# Altium schematic sheet main.SchDoc(main)
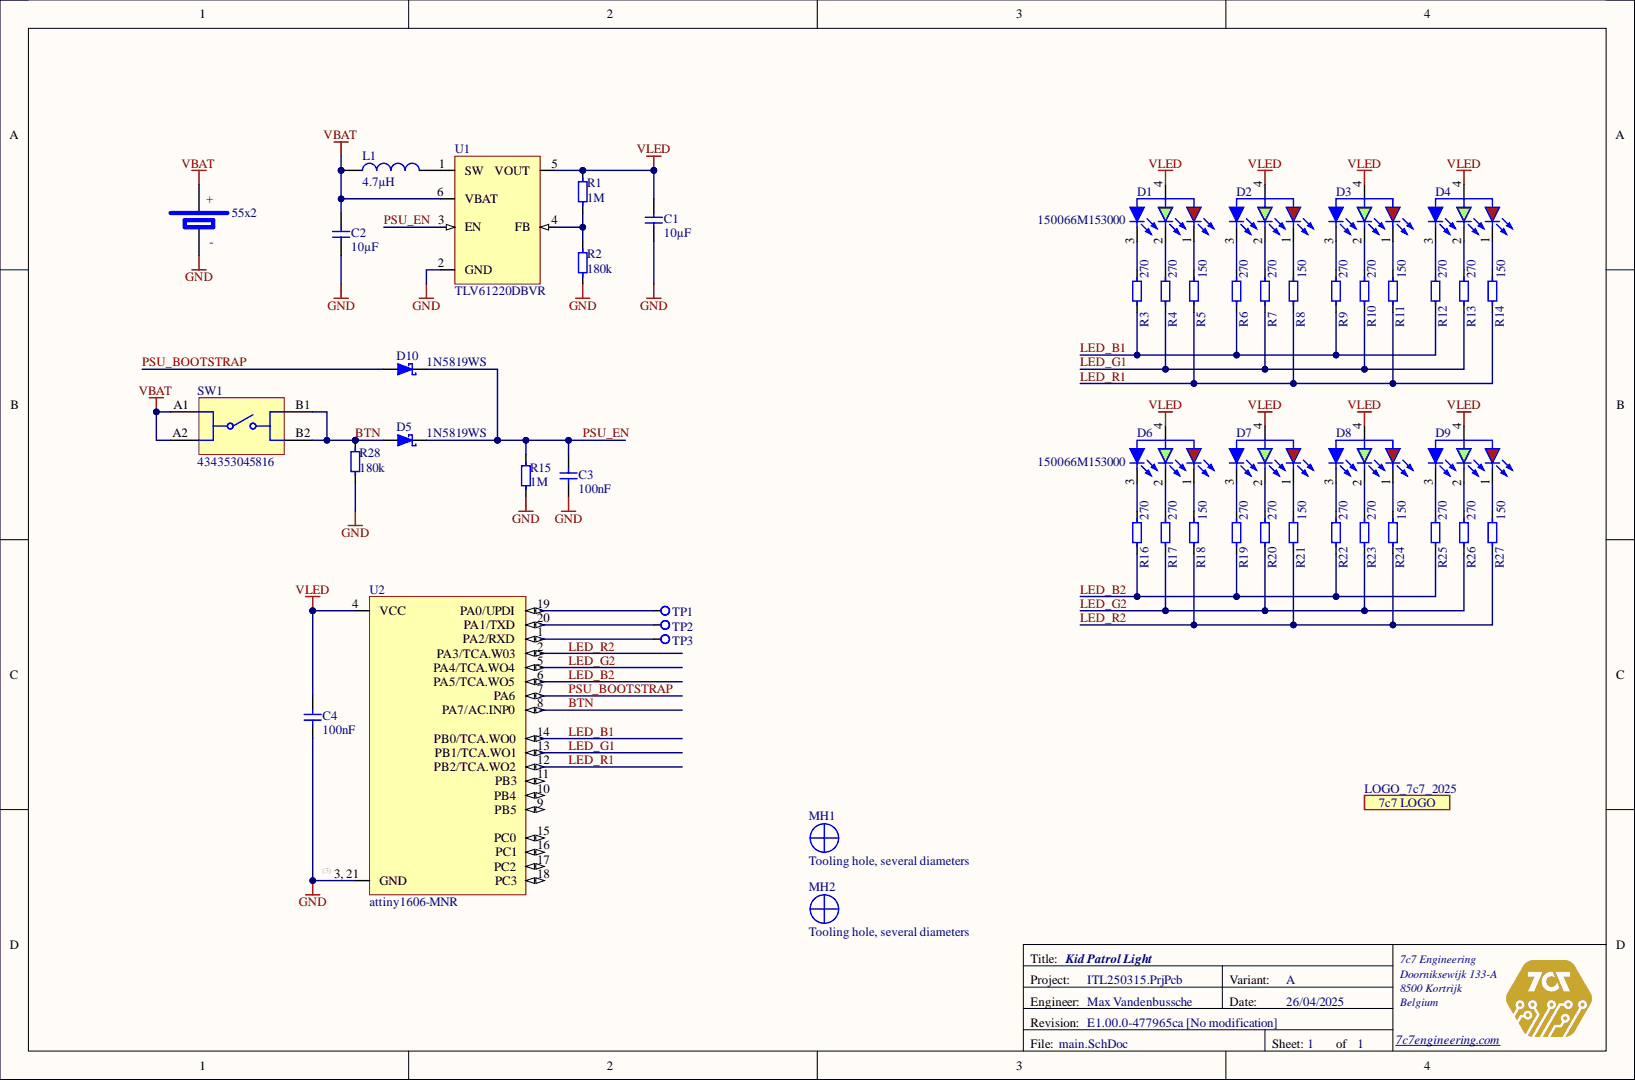
[diagram p0: main.SchDoc]

## Parts
BT1  55x2  [55x2]
C1  value 10µF  [0603-C-L]  (hidden comment: Capacitor 10µF +/-10% 10V X5R 0603)
C2  value 10µF  [0603-C-L]  (hidden comment: Capacitor 10µF +/-10% 10V X5R 0603)
C3  value 100nF  [0603-C-L]  (hidden comment: Capacitor 100nF +/-10% 10V X7R 0603)
C4  value 100nF  [0603-C-L]  (hidden comment: Capacitor 100nF +/-10% 10V X7R 0603)
D1  150066M153000  [WL-SFCD_0606]
D2  150066M153000  [WL-SFCD_0606]
D3  150066M153000  [WL-SFCD_0606]
D4  150066M153000  [WL-SFCD_0606]
D5  1N5819WS  [SOD323-IPC_C]
D6  150066M153000  [WL-SFCD_0606]
D7  150066M153000  [WL-SFCD_0606]
D8  150066M153000  [WL-SFCD_0606]
D9  150066M153000  [WL-SFCD_0606]
D10  1N5819WS  [SOD323-IPC_C]
GR1  LOGO_7c7_2025  [LOGO_7c7_2025_Silk_6mm]  (no BOM)
L1  Inductance: 4.7µH  [WE-PMI_1008_L2.5W2H1.2]  (hidden comment: 74479889247)
MH1  Tooling hole, several diameters  [ToolingHole_2.2mm]  (no BOM)
MH2  Tooling hole, several diameters  [ToolingHole_2.2mm]  (no BOM)
R1  value 1M  [0603-R-N]  (hidden comment: Resistor 1M +/-1% 50V 0603)
R2  value 180k  [0603-R-N]  (hidden comment: Resistor 180k +/-1% 75V 0603)
R3  value 270  [0603-R-N]  (hidden comment: Resistor 270R +/-1% 50V 0603)
R4  value 270  [0603-R-N]  (hidden comment: Resistor 270R +/-1% 50V 0603)
R5  value 150  [0603-R-N]  (hidden comment: Resistor 150R +/-1% 50V 0603)
R6  value 270  [0603-R-N]  (hidden comment: Resistor 270R +/-1% 50V 0603)
R7  value 270  [0603-R-N]  (hidden comment: Resistor 270R +/-1% 50V 0603)
R8  value 150  [0603-R-N]  (hidden comment: Resistor 150R +/-1% 50V 0603)
R9  value 270  [0603-R-N]  (hidden comment: Resistor 270R +/-1% 50V 0603)
R10  value 270  [0603-R-N]  (hidden comment: Resistor 270R +/-1% 50V 0603)
R11  value 150  [0603-R-N]  (hidden comment: Resistor 150R +/-1% 50V 0603)
R12  value 270  [0603-R-N]  (hidden comment: Resistor 270R +/-1% 50V 0603)
R13  value 270  [0603-R-N]  (hidden comment: Resistor 270R +/-1% 50V 0603)
R14  value 150  [0603-R-N]  (hidden comment: Resistor 150R +/-1% 50V 0603)
R15  value 1M  [0603-R-N]  (hidden comment: Resistor 1M +/-1% 50V 0603)
R16  value 270  [0603-R-N]  (hidden comment: Resistor 270R +/-1% 50V 0603)
R17  value 270  [0603-R-N]  (hidden comment: Resistor 270R +/-1% 50V 0603)
R18  value 150  [0603-R-N]  (hidden comment: Resistor 150R +/-1% 50V 0603)
R19  value 270  [0603-R-N]  (hidden comment: Resistor 270R +/-1% 50V 0603)
R20  value 270  [0603-R-N]  (hidden comment: Resistor 270R +/-1% 50V 0603)
R21  value 150  [0603-R-N]  (hidden comment: Resistor 150R +/-1% 50V 0603)
R22  value 270  [0603-R-N]  (hidden comment: Resistor 270R +/-1% 50V 0603)
R23  value 270  [0603-R-N]  (hidden comment: Resistor 270R +/-1% 50V 0603)
R24  value 150  [0603-R-N]  (hidden comment: Resistor 150R +/-1% 50V 0603)
R25  value 270  [0603-R-N]  (hidden comment: Resistor 270R +/-1% 50V 0603)
R26  value 270  [0603-R-N]  (hidden comment: Resistor 270R +/-1% 50V 0603)
R27  value 150  [0603-R-N]  (hidden comment: Resistor 150R +/-1% 50V 0603)
R28  value 180k  [0603-R-N]  (hidden comment: Resistor 180k +/-1% 75V 0603)
SW1  434353045816  [434353045816]
TP1  Testpad with multiple sizes  [TP_1MM]  (value not drawn)  (no BOM)
TP2  Testpad with multiple sizes  [TP_1MM]  (value not drawn)  (no BOM)
TP3  Testpad with multiple sizes  [TP_1MM]  (value not drawn)  (no BOM)
U1  TLV61220DBVR  [SOT-23-6]
U2  attiny1606-MNR  [QFN40P300X300X90_HS-21L]

## Nets
GND: BT1.2 C1.1 C2.1 C3.1 C4.1 R2.1 R15.1 R28.1 U1.2(GND) U2.3(GND)
VBAT: BT1.1 C2.2 L1.1 SW1.A1 SW1.A2 U1.6(VBAT)
VLED: C1.2 C4.2 D1.4 D2.4 D3.4 D4.4 D6.4 D7.4 D8.4 D9.4 R1.2 U1.5(VOUT) U2.4(VCC)
BTN: D5.2 R28.2 SW1.B1 SW1.B2 U2.8(PA7/AC.INP0)
LED_B1: R3.1 R6.1 R9.1 R12.1 U2.14(PB0/TCA.WO0)
LED_B2: R16.1 R19.1 R22.1 R25.1 U2.6(PA5/TCA.WO5)
LED_G1: R4.1 R7.1 R10.1 R13.1 U2.13(PB1/TCA.WO1)
LED_G2: R17.1 R20.1 R23.1 R26.1 U2.5(PA4/TCA.WO4)
LED_R1: R5.1 R8.1 R11.1 R14.1 U2.12(PB2/TCA.WO2)
LED_R2: R18.1 R21.1 R24.1 R27.1 U2.2(PA3/TCA.W03)
PSU_BOOTSTRAP: D10.2 U2.7(PA6)
PSU_EN: C3.2 D5.1 D10.1 R15.2 U1.3(EN)
NetD1_1: D1.1 R5.2
NetD1_2: D1.2 R4.2
NetD1_3: D1.3 R3.2
NetD2_1: D2.1 R8.2
NetD2_2: D2.2 R7.2
NetD2_3: D2.3 R6.2
NetD3_1: D3.1 R11.2
NetD3_2: D3.2 R10.2
NetD3_3: D3.3 R9.2
NetD4_1: D4.1 R14.2
NetD4_2: D4.2 R13.2
NetD4_3: D4.3 R12.2
NetD6_1: D6.1 R18.2
NetD6_2: D6.2 R17.2
NetD6_3: D6.3 R16.2
NetD7_1: D7.1 R21.2
NetD7_2: D7.2 R20.2
NetD7_3: D7.3 R19.2
NetD8_1: D8.1 R24.2
NetD8_2: D8.2 R23.2
NetD8_3: D8.3 R22.2
NetD9_1: D9.1 R27.2
NetD9_2: D9.2 R26.2
NetD9_3: D9.3 R25.2
NetL1_2: L1.2 U1.1(SW)
NetR1_1: R1.1 R2.2 U1.4(FB)
NetTP1_1: TP1.1 U2.19(PA0/UPDI)
NetTP2_1: TP2.1 U2.20(PA1/TXD)
NetTP3_1: TP3.1 U2.1(PA2/RXD)

Not connected: MH1 1; MH2 1; U2 9(PB5) 10(PB4) 11(PB3) 15(PC0) 16(PC1) 17(PC2) 18(PC3)

## Library data of the parts
BT1 = CMP-001-0004-5: Battery holder 2 x Keystone 55, AAA; Component Kind: Standard; Contact Material: Steel; Contact Plating: Tin; Lead Free: Lead Free; Library Name: 7c7 Engineering; REACH SVHC: No SVHC
C1 C2 = CMP-001-00075-16: Capacitor MLCC, General Purpose, size 0603, X5R, 10µF, +/-10%, 10V; Tolerance: 10%; Capacitor Type: MLCC; Case/Package: 0603; Component Kind: Standard; Dielectric: X5R; Height: 0.8mm; Length: 1.6mm; Library Name: 7c7 Engineering; Max Operating Temperature: 85°C; Min Operating Temperature: -55°C; Mounting Technology: SMT; Pins: 2; Usage: General Purpose; Voltage Rating: 10V; Width: 0.8mm
C3 C4 = CMP-001-00113-10: Capacitor MLCC, General Purpose, size 0603, X7R, 100nF, +/-10%, 10V; Ripple Current: 10; Tolerance: 10%; Capacitor Type: MLCC; Case/Package: 0603; Component Kind: Standard; Dielectric: X7R; Height: 0.8mm; JLCPCB Part #: C14663; Length: 1.6mm; Library Name: 7c7 Engineering; Max Operating Temperature: 125°C; Min Operating Temperature: -55°C; Mounting Technology: SMT; Pins: 2; RoHS Compliant: True; Usage: General Purpose; Voltage Rating: 10V; Width: 0.8mm
D1-D4 D6-D9 = CMP-002-001111-4: WL-SFCD SMT Full-color Chip LED Diffused, size 0606, Red & Green & Blue, 120°; Manufacturer: Wurth Elektronik; Case/Size Code: 0606; Chip Technology: AlInGaP + InGaN; Dominant Wavelength (Blue): 470nm; Dominant Wavelength (Green): 525nm; Dominant Wavelength (Red): 624nm; Forward Voltage (Blue): 3.3V; Forward Voltage (Red): 2V; Luminous Intensity (Blue): 180mcd; Luminous Intensity (Green): 900mcd; Luminous Intensity (Red): 285mcd; Peak Wavelength (Blue): 468nm; Peak Wavelength (Green): 520nm; Peak Wavelength (Red): 632nm; Category: Signal LEDs; Component Kind: Standard; Component Type: Standard; ComponentLink1Description: Website Link; ComponentLink1URL: https://www.we-online.com/redexpert/article/150066M153000?ad; ComponentLink2Description: Datasheet Link; ComponentLink2URL: https://www.we-online.com/redexpert/spec/150066M153000?ad; Design Item ID: 150066M153000; Emitting Color: Red & Green & Blue; Forward Voltage (Green): 3.3V; Height: 0.4mm; JLCPCB Part #: C458749; Length: 1.6mm; Library Name: 7c7 Engineering; Manufacturer Part Number: 150066M153000; Match Code: WL-SFCD; Mount: Surface Mount; Operating Temperature Max: 85°C; Operating Temperature Min: -40°C; Packaging: Tape and Reel; Pin Count: 4; Source: WL-SFCD.SchLib; Viewing Angle: 120°; Width: 1.6mm
D5 D10 = CMP-004-00055-1: Diode Schottky 40V 0.01A 2-Pin SOD-323 T/R - Product that comes on tape, but is not reeled; Average Rectified Current: 1A; Element Configuration: Single; Forward Current: 1mA; Forward Voltage: 800mV; Max Forward Surge Current (Ifsm): 25A; Max Repetitive Reverse Voltage (Vrrm): 28V; Max Reverse Current: 500nA; Polarity: Standard; Power Dissipation: 200mW; Breakdown Voltage: 40V; Case/Package: SOD-323-2; Component Kind: Standard; Current Rating: 1A; Height: 0.9mm; JLCPCB Part #: C191023; Lead Free: Lead Free; Length: 1.7mm; Library Name: 7c7 Engineering; Max Operating Temperature: 110°C; Max Reverse Leakage Current: 500nA; Max Reverse Voltage (DC): 40V; Max Surge Current: 25A; Min Operating Temperature: -55°C; Output Current: 1A; Package Quantity: 3000; Packaging: Tape and Reel; Peak Non-Repetitive Surge Current: 25A; Pins: 2; Radiation Hardening: No; Reach SVHC Compliant: No; Reverse Voltage: 40V; RoHS Compliant: No; Series: -; Value: 1N5819WS; Voltage Rating (DC): 40V; Width: 1.25mm
GR1 = CMP-001-0050-1: The 7c7 logo: Be proud of our designs and stamp that logo on there!; Library Name: 7c7 Engineering
L1 = CMP-068-000065-5: WE-PMI SMT Power Multilayer Inductor, 1008, 4.7µH, 0.9A, 500mO; Manufacturer: Wurth Elektronik; Case/Size Code: 1008; Inductance Tolerance: 20%; Q Factor: 15; Self Resonant Frequency: 35MHz; Type: High Saturation Current; Category: Shielded Power Inductors; Component Kind: Standard; Component Type: Standard; ComponentLink1Description: Website Link; ComponentLink1URL: https://www.we-online.com/redexpert/article/74479889247?ad; ComponentLink2Description: Datasheet Link; ComponentLink2URL: https://www.we-online.com/redexpert/spec/74479889247?ad; DC Resistance: 500mO; Design Item ID: 74479889247; Height: 1.2mm; Length: 2.5mm; Library Name: 7c7 Engineering; Manufacturer Part Number: 74479889247; Match Code: WE-PMI; Mount: Surface Mount; Operating Temperature Max: 125°C; Operating Temperature Min: -40°C; Packaging: Tape and Reel; Pin Count: 2; Rated Current: 0.9A; Saturation Current: 0.9A; Source: WE-PMI.SchLib; Width: 2mm
MH1 MH2 = CMP-00028-00011-7: Tooling hole for alignment: ⌀2.2mm, ⌀2.6mm, ⌀3.2mm, ...; Library Name: 7c7 Engineering
R1 R15 = CMP-009-00234-17: Chip Resistor, Thick Film, General Purpose, 0603, 100mW, 50V, 1 MOhm, +/-1%; Elements: 1; Tolerance: 1%; Case/Package: 0603; Component Kind: Standard; JLCPCB Part #: C22935; Library Name: 7c7 Engineering; Max Operating Temperature: 155°C; Min Operating Temperature: -55°C; Mounting Technology: SMT; Pins: 2; Power Rating: 100mW; Resistor Type: Thick Film; RoHS Compliant: Yes; Usage: General Purpose; Voltage Rating: 50V
R2 R28 = CMP-009-00290-10: Res Thick Film 0603 180K Ohm 1% 0.1W(1/10W) ±100ppm/C Pad SMD T/R; Elements: 1; Power Rating: 100mW; Temperature Coefficient: 100ppm/°C; Usage: General Purpose; Case/Package: 0603; Component Kind: Standard; Features: Moisture Resistant; JLCPCB Part #: C22827; Lead Free: Lead Free; Library Name: 7c7 Engineering; Max Operating Temperature: 155°C; Min Operating Temperature: -55°C; Mounting Technology: SMT; Pins: 2; Resistor Type: Thick Film; RoHS Compliant: Yes; Tolerance: 1%; Voltage Rating: 75V
R3 R4 R6 R7 R9 R10 R12 R13 R16 R17 R19 R20 R22 R23 R25 R26 = CMP-009-00178-27: Chip Resistor, Thick Film, General Purpose, 0603, 100mW, 50V, 270 Ohm, +/-1%; Elements: 1; Tolerance: 1%; Case/Package: 0603; Component Kind: Standard; JLCPCB Part #: C22966; Library Name: 7c7 Engineering; Max Operating Temperature: 155°C; Min Operating Temperature: -55°C; Mounting Technology: SMT; Pins: 2; Power Rating: 100mW; Resistor Type: Thick Film; Usage: General Purpose; Voltage Rating: 50V
R5 R8 R11 R14 R18 R21 R24 R27 = CMP-009-00250-17: Chip Resistor, Thick Film, General Purpose, 0603, 100mW, 50V, 150 Ohm, +/-1%; Page Part #: ROQF1500; Elements: 1; Temperature Coefficient: 100ppm/°C; Tolerance: 1%; Case/Package: 0603; Component Kind: Standard; JLCPCB Part #: C227596; Library Name: 7c7 Engineering; Max Operating Temperature: 155°C; Min Operating Temperature: -55°C; Mounting Technology: SMT; Pins: 2; Power Rating: 100mW; REACH SVHC: No SVHC; Resistor Type: Thick Film; Usage: General Purpose; Voltage Rating: 50V
SW1 = CMP-002-000250-1: WS-TASU J-Bend SMT Tact Switch 4.7x3.5mm side push, SPST-NO, 12VDC, 50mA, 160g; Manufacturer: Wurth Elektronik; Washable: No; Category: Tact Switches; Circuit: SPST-NO; Component Kind: Standard; Component Type: Standard; ComponentLink1Description: Website Link; ComponentLink1URL: https://www.we-online.com/redexpert/article/434353045816?ad; ComponentLink2Description: Datasheet Link; ComponentLink2URL: https://www.we-online.com/redexpert/spec/434353045816?ad; Contact Material: Stainless Steel; Contact Plating: Silver; Design Item ID: 434353045816; Height: 1.65mm; Length: 4.7mm; Library Name: 7c7 Engineering; Manufacturer Part Number: 434353045816; Match Code: WS-TASU; Mount: Surface Mount; Operating Temperature Max: 85°C; Operating Temperature Min: -40°C; Operation Force: 160g; Packaging: Tape and Reel; Pin Count: 4; Rated Current: 50mA; Rated Voltage: 12VDC; Source: WS-TASU.SchLib; Terminal Material: Copper Alloy; Terminal Plating: Silver; Width: 3.5mm
TP1-TP3 = CMP-027-000000-17: Testpad land; Component Type: Standard; Design Item ID: Testpad; Library Name: 7c7 Engineering; Pin Count: 1
U1 = CMP-000061-2: Boost Switching Regulator IC Positive Adjustable 1.8V 1 Output 220mA (Switch) SOT-23-6; Efficiency: 95%; Max Output current: 400mA; Max Output Voltage: 5.5V; Min Input Voltage: 700mV; Number of Outputs: 1; Output Current: 200mA; Quiescent Current: 5uA; Switching Frequency: 2MHz; Synchronous Rectifier: Yes; Case/Package: SOT-23-6; Component Kind: Standard; Height: 1.3mm; Lead Free: Lead Free; Library Name: 7c7 Engineering; Max Operating Temperature: 85°C; Max Supply Voltage: 5.5V; Min Operating Temperature: -40°C; Min Output Voltage: 1.8V; Mounting Technology: Surface Mount; Output Configuration: Positive; Output Type: Adjustable; Output Voltage: 5.5V; Topology: Boost
U2 = CMP-020-00042-1: 8-bit Microcontrollers - MCU 20MHz, 16KB, VQFN20, Ind 105C, Green, T&R; Component Kind: Standard; Library Name: 7c7 Engineering
